# Altium schematic sheet PIC24_study_kit.SchDoc(PIC24_study_kit)
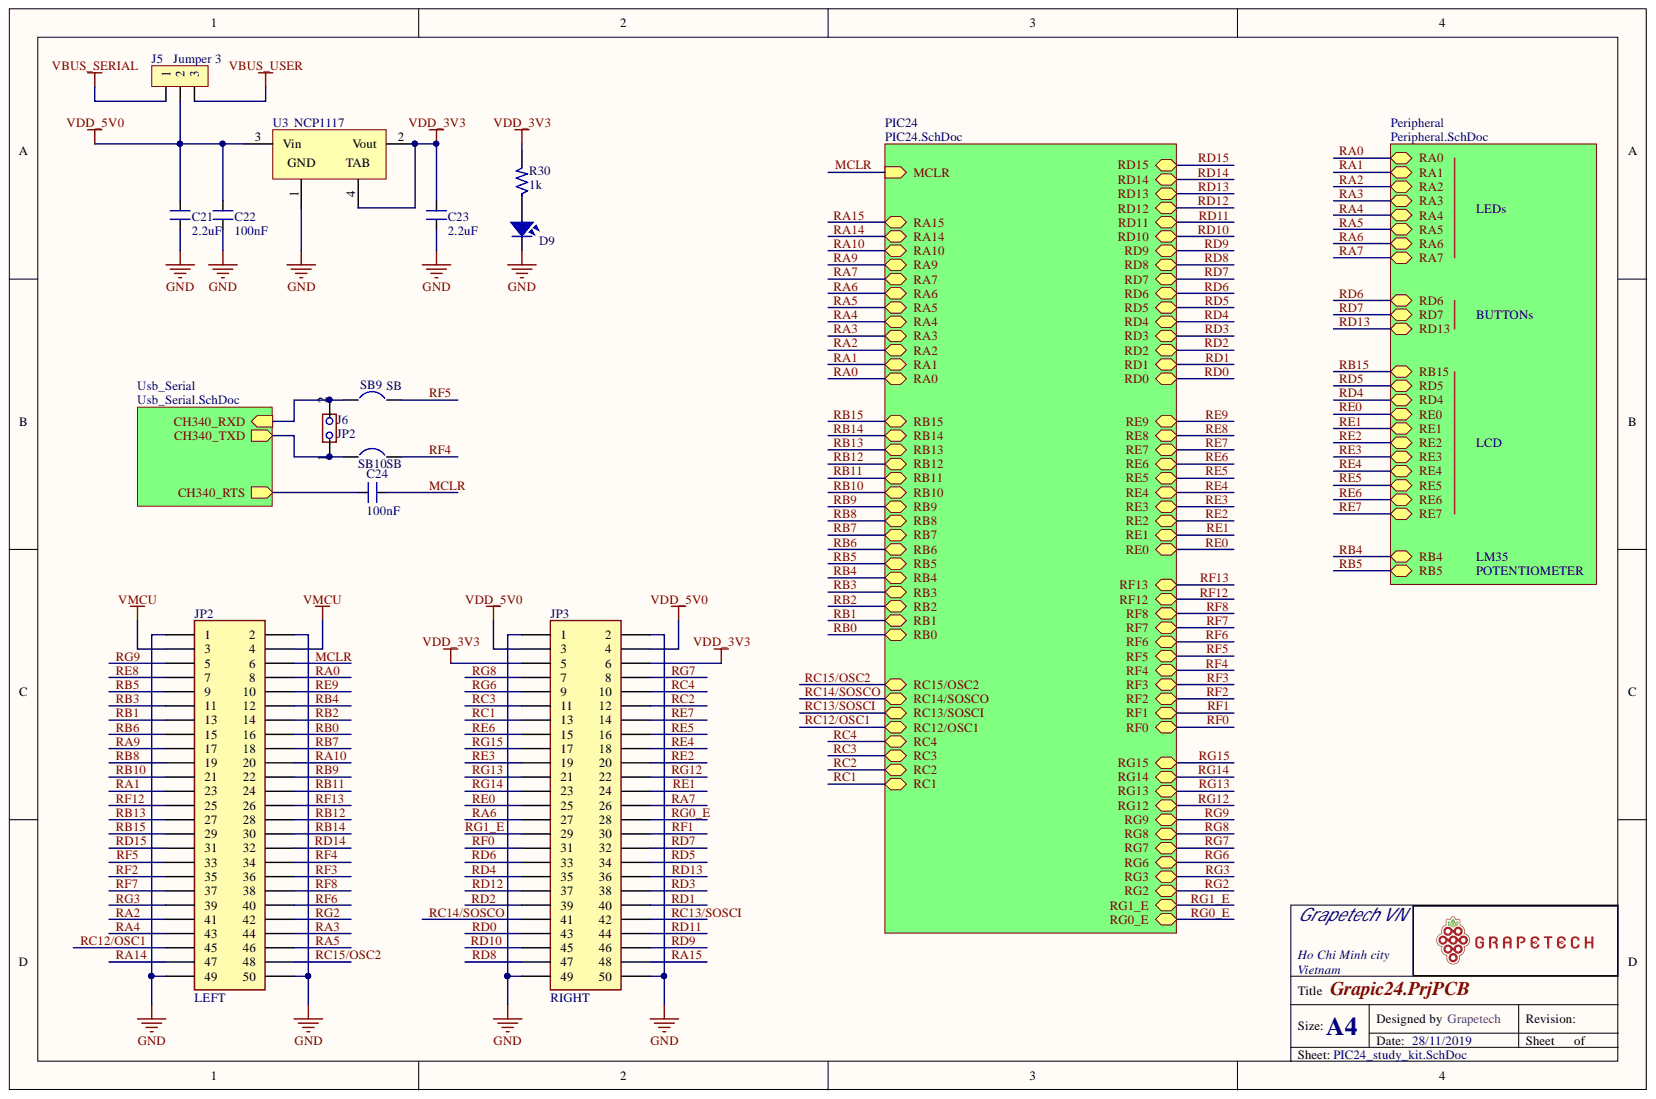
[diagram p0: PIC24_study_kit.SchDoc]

## Parts
C21  2.2uF  [0603_cap]
C22  100nF  [0603_cap]
C23  2.2uF  [0603_cap]
C24  100nF  [0402_cap]
D9  LED SMD  [0603_LED]  (value not drawn)
J5  Jumper 3  [JP3]
J6  JP2  [JP2]
JP2  LEFT  [JP25X2]
JP3  RIGHT  [JP25X2]
R30  1k  [0402_res]
SB9  SB  [SB0603]
SB10  SB  [SB0603]
U3  NCP1117  [SOT223_L]

## Nets
GND: C21.1 C22.1 C23.1 D9.2 JP2.1 JP2.2 JP2.49 JP2.50 JP3.1 JP3.2 JP3.49 JP3.50 U3.1
VBUS_SERIAL: J5.1
VBUS_USER: J5.3
VDD_3V3: C23.2 JP3.5 JP3.6 R30.2 U3.2(Vout) U3.4
VDD_5V0: C21.2 C22.2 J5.2 JP3.3 JP3.4 U3.3(Vin)
VMCU: JP2.3 JP2.4
MCLR: C24.2 JP2.6
RA0: JP2.8
RA1: JP2.23
RA2: JP2.41
RA3: JP2.44
RA4: JP2.43
RA5: JP2.46
RA6: JP3.27
RA7: JP3.26
RA9: JP2.17
RA10: JP2.20
RA14: JP2.47
RA15: JP3.48
RB0: JP2.16
RB1: JP2.13
RB2: JP2.14
RB3: JP2.11
RB4: JP2.12
RB5: JP2.9
RB6: JP2.15
RB7: JP2.18
RB8: JP2.19
RB9: JP2.22
RB10: JP2.21
RB11: JP2.24
RB12: JP2.28
RB13: JP2.27
RB14: JP2.30
RB15: JP2.29
RC1: JP3.13
RC2: JP3.12
RC3: JP3.11
RC4: JP3.10
RC12/OSC1: JP2.45
RC13/SOSCI: JP3.42
RC14/SOSCO: JP3.41
RC15/OSC2: JP2.48
RD0: JP3.43
RD1: JP3.40
RD2: JP3.39
RD3: JP3.38
RD4: JP3.35
RD5: JP3.34
RD6: JP3.33
RD7: JP3.32
RD8: JP3.47
RD9: JP3.46
RD10: JP3.45
RD11: JP3.44
RD12: JP3.37
RD13: JP3.36
RD14: JP2.32
RD15: JP2.31
RE0: JP3.25
RE1: JP3.24
RE2: JP3.20
RE3: JP3.19
RE4: JP3.18
RE5: JP3.16
RE6: JP3.15
RE7: JP3.14
RE8: JP2.7
RE9: JP2.10
RF0: JP3.31
RF1: JP3.30
RF2: JP2.35
RF3: JP2.36
RF4: JP2.34 SB10.2
RF5: JP2.33 SB9.2
RF6: JP2.40
RF7: JP2.37
RF8: JP2.38
RF12: JP2.25
RF13: JP2.26
RG0_E: JP3.28
RG1_E: JP3.29
RG2: JP2.42
RG3: JP2.39
RG6: JP3.9
RG7: JP3.8
RG8: JP3.7
RG9: JP2.5
RG12: JP3.22
RG13: JP3.21
RG14: JP3.23
RG15: JP3.17
NetC24_1: C24.1
NetD9_1: D9.1 R30.1
NetJ6_1: J6.1 SB10.1
NetJ6_2: J6.2 SB9.1

## Library data of the parts
C21-C24 = CM: Cap SMD; Value: 103; Library Name: MyLib.SchLib; Component Kind: Standard
D9 = LED-SMD-0603: Library Name: MyLib.SchLib; Component Kind: Standard
J5 = JP3: Value: J3; Library Name: MyLib.SchLib; Description: Jumper 3; Component Kind: Standard
J6 = JP2_1: Library Name: MyLib.SchLib; Component Kind: Standard
JP2 JP3 = JP25X2: Library Name: MyLib.SchLib; Component Kind: Standard
R30 = R: Resistor 1/4W; Value: R1/4W - 1K; Library Name: MyLib.SchLib; Component Kind: Standard
SB9 SB10 = SB: Library Name: MyLib.SchLib; Component Kind: Standard
U3 = NCP1117: Library Name: MyLib.SchLib; Component Kind: Standard
